# Altium schematic sheet Com Interface.SchDoc("Com Interface")
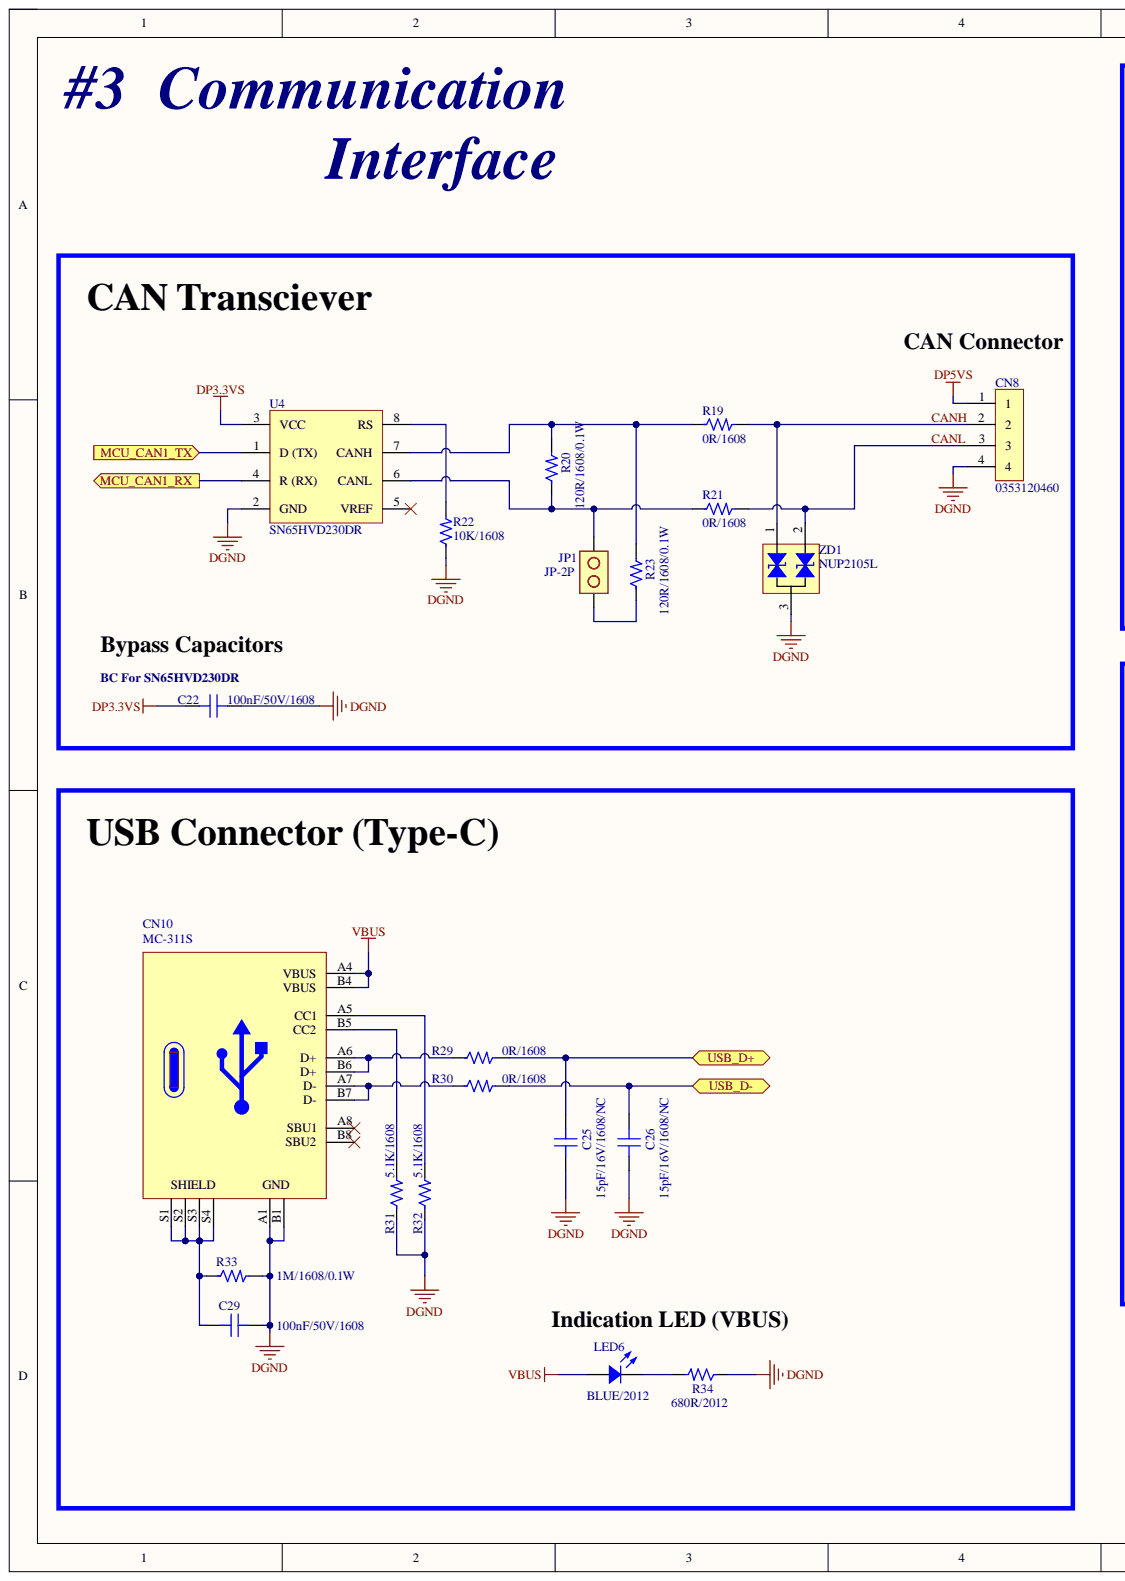
[diagram p0: Com Interface.SchDoc, left]
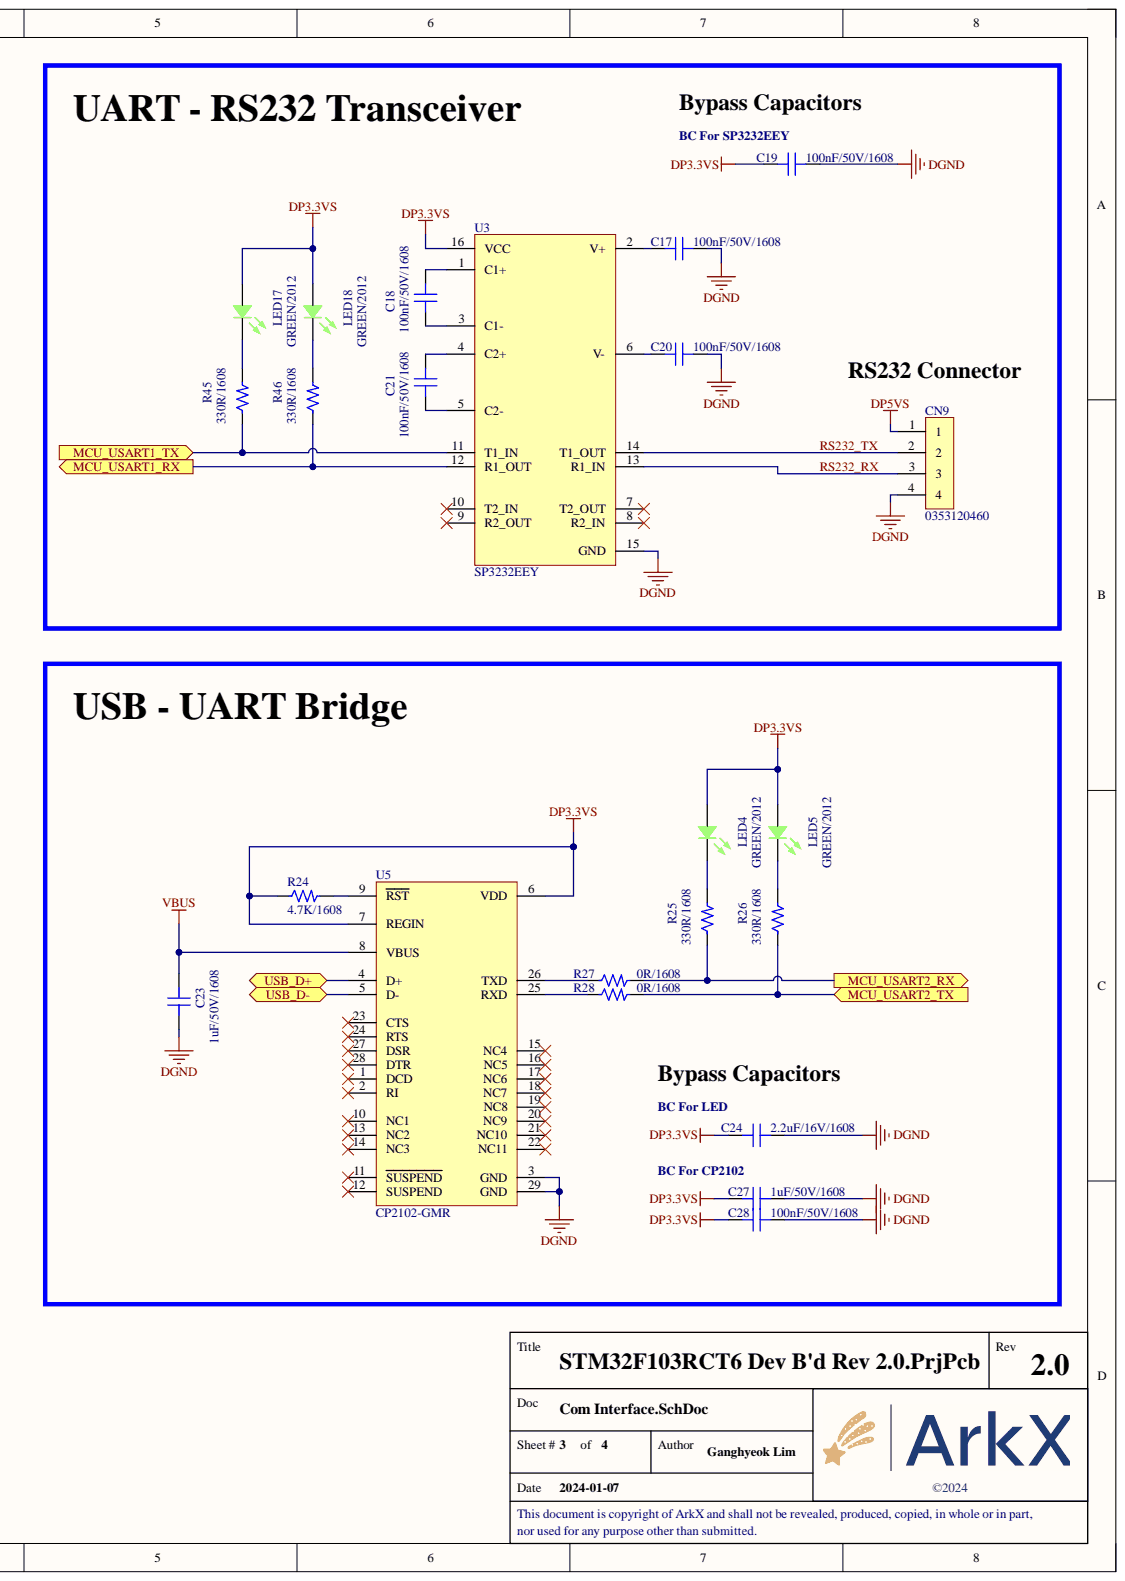
[diagram p1: Com Interface.SchDoc, right]

## Parts
C17  100nF/50V/1608  [CAP 1608 (0603_INCH)]
C18  100nF/50V/1608  [CAP 1608 (0603_INCH)]
C19  100nF/50V/1608  [CAP 1608 (0603_INCH)]
C20  100nF/50V/1608  [CAP 1608 (0603_INCH)]
C21  100nF/50V/1608  [CAP 1608 (0603_INCH)]
C22  100nF/50V/1608  [CAP 1608 (0603_INCH)]
C23  1uF/50V/1608  [CAP 1608 (0603_INCH)]
C24  2.2uF/16V/1608  [CAP 1608 (0603_INCH)]
C25  15pF/16V/1608/NC  [CAP 1608 (0603_INCH)/NC]
C26  15pF/16V/1608/NC  [CAP 1608 (0603_INCH)/NC]
C27  1uF/50V/1608  [CAP 1608 (0603_INCH)]
C28  100nF/50V/1608  [CAP 1608 (0603_INCH)]
C29  100nF/50V/1608  [CAP 1608 (0603_INCH)]
CN8  0353120460  [CON 0353120460 (MOLEX)]
CN9  0353120460  [CON 0353120460 (MOLEX)]
CN10  MC-311S  [CON USB-Type-C (16P)]
JP1  JP-2P  [CON PIN_HEADER-2PIN(2.54MM)]
LED4  GREEN/2012  [LED MHT170RGBCT (GREEN)]
LED5  GREEN/2012  [LED MHT170RGBCT (GREEN)]
LED6  BLUE/2012  [LED MHT170RGBCT (BLUE)]
LED17  GREEN/2012  [LED MHT170RGBCT (GREEN)]
LED18  GREEN/2012  [LED MHT170RGBCT (GREEN)]
R19  0R/1608  [RES 1608 (0603_inch)]
R20  120R/1608/0.1W  [RES 1608 (0603_inch)]
R21  0R/1608  [RES 1608 (0603_inch)]
R22  10K/1608  [RES 1608 (0603_inch)]
R23  120R/1608/0.1W  [RES 1608 (0603_inch)]
R24  4.7K/1608  [RES 1608 (0603_inch)]
R25  330R/1608  [RES 1608 (0603_inch)]
R26  330R/1608  [RES 1608 (0603_inch)]
R27  0R/1608  [RES 1608 (0603_inch)]
R28  0R/1608  [RES 1608 (0603_inch)]
R29  0R/1608  [RES 1608 (0603_inch)]
R30  0R/1608  [RES 1608 (0603_inch)]
R31  5.1K/1608  [RES 1608 (0603_inch)]
R32  5.1K/1608  [RES 1608 (0603_inch)]
R33  1M/1608/0.1W  [RES 1608 (0603_inch)]
R34  680R/2012  [RES 2012 (0805_inch)]
R45  330R/1608  [RES 1608 (0603_inch)]
R46  330R/1608  [RES 1608 (0603_inch)]
U3  SP3232EEY  [TSSOP-16]
U4  SN65HVD230DR  [SOIC-8 R8 (ANALOG DEV)]
U5  CP2102-GMR  [QFN-28 (5x5mm)]
ZD1  NUP2105L  [SOT 23-3 (DIODES INCORPORATED)]

## Ports
MCU_CAN1_RX, MCU_CAN1_TX, MCU_USART1_RX, MCU_USART1_TX, MCU_USART2_RX, MCU_USART2_TX, USB_D+, USB_D-

## Nets
DGND: C17.2 C19.1 C20.2 C22.1 C23.1 C24.2 C25.1 C26.1 C27.1 C28.1 C29.2 CN8.4 CN9.4 CN10.A1 CN10.B1 R22.1 R31.1 R32.1 R33.2 R34.1 U3.15(GND) U4.2(GND) U5.3(GND) U5.29(GND) ZD1.3
DP3.3VS: C19.2 C22.2 C24.1 C27.2 C28.2 LED4.4 LED5.4 LED17.4 LED18.4 R24.1 U3.16(VCC) U4.3(VCC) U5.6(VDD) U5.7(REGIN)
DP5VS: CN8.1 CN9.1
VBUS: C23.2 CN10.A4(VBUS) CN10.B4(VBUS) LED6.4 U5.8(VBUS)
CANH: CN8.2 R19.2 ZD1.1
CANL: CN8.3 R21.2 ZD1.2
MCU_CAN1_RX: U4.4(R_(RX)) ; port MCU_CAN1_RX
MCU_CAN1_TX: U4.1(D_(TX)) ; port MCU_CAN1_TX
MCU_USART1_RX: R46.1 U3.12(R1_OUT) ; port MCU_USART1_RX
MCU_USART1_TX: R45.1 U3.11(T1_IN) ; port MCU_USART1_TX
MCU_USART2_RX: R25.1 R27.2 ; port MCU_USART2_RX
MCU_USART2_TX: R26.1 R28.2 ; port MCU_USART2_TX
RS232_RX: CN9.3 U3.13(R1_IN)
RS232_TX: CN9.2 U3.14(T1_OUT)
USB_D+: C25.2 R29.2 U5.4(D+) ; port USB_D+
USB_D-: C26.2 R30.2 U5.5(D-) ; port USB_D-
NetC17_1: C17.1 U3.2(V+)
NetC18_1: C18.1 U3.3(C1-)
NetC18_2: C18.2 U3.1(C1+)
NetC20_1: C20.1 U3.6(V-)
NetC21_1: C21.1 U3.5(C2-)
NetC21_2: C21.2 U3.4(C2+)
NetC29_1: C29.1 CN10.S1 CN10.S2 CN10.S3 CN10.S4 R33.1
NetCN10_A5: CN10.A5(CC1) R32.2
NetCN10_A6: CN10.A6(D+) CN10.B6(D+) R29.1
NetCN10_A7: CN10.A7(D-) CN10.B7(D-) R30.1
NetCN10_B5: CN10.B5(CC2) R31.2
NetJP1_1: JP1.1 R23.1
NetJP1_2: JP1.2 R20.1 R21.1 U4.6(CANL)
NetLED4_2: LED4.2 R25.2
NetLED5_2: LED5.2 R26.2
NetLED6_3: LED6.3 R34.2
NetLED17_2: LED17.2 R45.2
NetLED18_2: LED18.2 R46.2
NetR19_1: R19.1 R20.2 R23.2 U4.7(CANH)
NetR22_2: R22.2 U4.8(RS)
NetR24_2: R24.2 U5.9(RST)
NetR27_1: R27.1 U5.26(TXD)
NetR28_1: R28.1 U5.25(RXD)

Not connected: CN10 A8(SBU1) B8(SBU2); U3 7(T2_OUT) 8(R2_IN) 9(R2_OUT) 10(T2_IN); U4 5(VREF); U5 1(DCD) 2(RI) 10(NC1) 11(SUSPEND) 12(SUSPEND) 13(NC2) 14(NC3) 15(NC4) 16(NC5) 17(NC6) 18(NC7) 19(NC8) 20(NC9) 21(NC10) 22(NC11) 23(CTS) 24(RTS) 27(DSR) 28(DTR)

## Library data of the parts
C17-C22 C28 C29 = CAP_100nF/50V/1608: Ceramic CAP_100nF/50V/1608; Component Kind: Standard; JLCPCB Part Type: Basic Part; LCSC Part #: C14663; Library Name: Arkx.IntLib
C23 C27 = CAP_1uF/50V/1608: Ceramic CAP_1uF/50V/1608; Component Kind: Standard; JLCPCB Part Type: Basic Part; LCSC Part #: C15849; Library Name: Arkx.IntLib
C24 = CAP_2.2uF/16V/1608: Ceramic CAP_2.2uF/16V/1608; Component Kind: Standard; JLCPCB Part Type: Basic Part; LCSC Part #: C23630; Library Name: Arkx.IntLib
C25 C26 = CAP_NC_15pF/16V/1608: Ceramic CAP_15pF/16V/1608; Component Kind: Standard; Library Name: Arkx.IntLib
CN8 CN9 = CON_0353120460: CONN HEADER VERT 4POS 2.5MM; Component Kind: Standard; Library Name: Arkx.IntLib
CN10 = CON_USB-Type-C: USB 2.0 5A 1 Surface Mount 16 Female -35℃~+75℃ Type-C SMD  USB Connectors ROHS; Component Kind: Standard; JLCPCB Part Type: Extended Part; LCSC Part #: C2977718; Library Name: Arkx.IntLib
JP1 = CON_JP-2P: Component Kind: Standard; Library Name: Arkx.IntLib
LED4 LED5 LED17 LED18 = LED_MHT170RGBCT(GREEN): Colorless transparence -30℃~+85℃ Positive post Common anode RGB 120° 0805  Light Emitting Diodes (LED) ROHS; Component Kind: Standard; JLCPCB Part Type: Extended Part; LCSC Part #: C2962094; Library Name: Arkx.IntLib
LED6 = LED_MHT170RGBCT(BLUE): Colorless transparence -30℃~+85℃ Positive post Common anode RGB 120° 0805  Light Emitting Diodes (LED) ROHS; Component Kind: Standard; JLCPCB Part Type: Extended Part; LCSC Part #: C2962094; Library Name: Arkx.IntLib
R19 R21 R27-R30 = RES_0R/1608/0.1W: Power Rating: 0.1W; Precision: 1%; Value: 0R; Component Kind: Standard; Description: RES_0R/1608/0.1W; JLCPCB Part Type: Basic Part; LCSC Part #: C21189; Size: 1608; Library Name: Arkx.IntLib
R20 R23 = RES_120R/1608/0.1W: Power Rating: 0.1W; Precision: 1%; Value: 120R; Component Kind: Standard; Description: RES_120R/1608/0.1W; JLCPCB Part Type: Basic Part; LCSC Part #: C22787; Size: 1608; Library Name: Arkx.IntLib
R22 = RES_10K/1608/0.1W: Power Rating: 0.1W; Precision: 1%; Value: 10K; Component Kind: Standard; Description: RES_10K/1608/0.1W; JLCPCB Part Type: Basic Part; LCSC Part #: C25804; Size: 1608; Library Name: Arkx.IntLib
R24 = RES_4.7K/1608/0.1W: Power Rating: 0.1W; Precision: 1%; Value: 4.7K; Component Kind: Standard; Description: RES_4.7K/1608/0.1W; JLCPCB Part Type: Basic Part; LCSC Part #: C23162; Size: 1608; Library Name: Arkx.IntLib
R25 R26 R45 R46 = RES_330R/1608/0.1W: Power Rating: 0.1W; Precision: 1%; Value: 330R; Component Kind: Standard; Description: RES_330R/1608/0.1W; JLCPCB Part Type: Basic Part; LCSC Part #: C23138; Size: 1608; Library Name: Arkx.IntLib
R31 R32 = RES_5.1K/1608/0.1W: Power Rating: 0.1W; Precision: 1%; Value: 5.1K; Component Kind: Standard; Description: RES_5.1K/1608/0.1W; JLCPCB Part Type: Basic Part; LCSC Part #: C23186; Size: 1608; Library Name: Arkx.IntLib
R33 = RES_1M/1608/0.1W: Power Rating: 0.1W; Precision: 1%; Value: 1M; Component Kind: Standard; Description: RES_1M/1608/0.1W; JLCPCB Part Type: Basic Part; LCSC Part #: C22935; Size: 1608; Library Name: Arkx.IntLib
R34 = RES_680R/2012/0.125W: Power Rating: 0.125W; Precision: 1%; Value: 680R; Component Kind: Standard; Description: RES_680R/2012/0.125W; JLCPCB Part Type: Basic Part; LCSC Part #: C17798; Size: 2012; Library Name: Arkx.IntLib
U3 = IC_RS232_SP3232EEY: 235Kbps 3V~5.5V transceiver 2/2 TSSOP-16  RS232 ICs ROHS; Component Kind: Standard; JLCPCB Part Type: Basic Part; LCSC Part #: C13482; Library Name: Arkx.IntLib
U4 = IC_CAN_SN65HVD230DR: IC TRANSCEIVER HALF 1/1 8SOIC; Component Kind: Standard; JLCPCB Part Type: Basic Part; LCSC Part #: C12084; Library Name: Arkx.IntLib
U5 = IC_USB_CP2102: Transceiver 1Mbps USB 1/1 QFN-28_5x5x05P  USB ICs ROHS; Component Kind: Standard; JLCPCB Part Type: Basic Part; LCSC Part #: C6568; Library Name: Arkx.IntLib
ZD1 = DIO_TVS_NUP2105L: SOT-23 ESD PROTECTION DEVICES RO; Component Kind: Standard; JLCPCB Part Type: Extended Part; LCSC Part #: C5158054; Library Name: Arkx.IntLib
